# Altium schematic sheet CONNECT.SchDoc
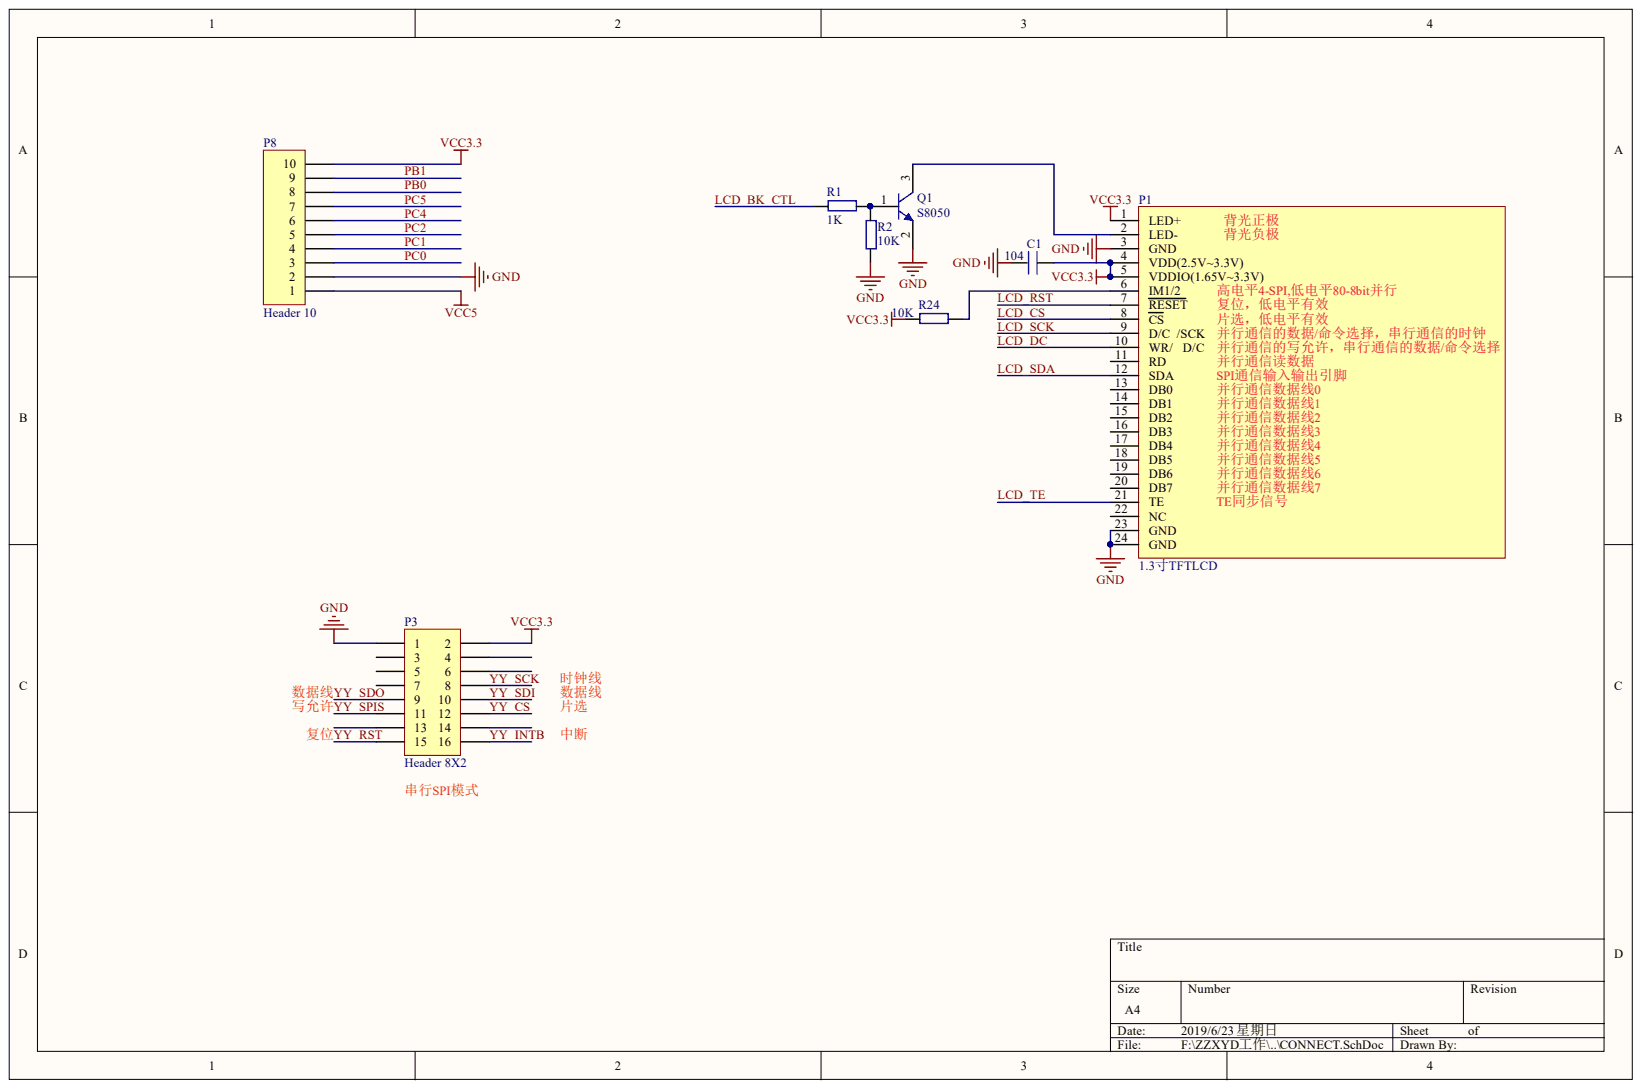
[diagram p0: CONNECT.SchDoc]

## Parts
C1  value 104  [0805C]  (hidden comment: CAP)
P1  1.3寸TFTLCD  [1.3寸屏]
P3  Header 8X2  [语音识别模块]
P8  Header 10  [HDR1X10]
Q1  S8050  [SOT-23]
R1  value 1K  [0805]  (hidden comment: RES)
R2  value 10K  [0805]  (hidden comment: RES)
R24  value 10K  [0805]  (hidden comment: RES)

## Nets
GND: C1.1 P1.3(GND) P1.23(GND) P1.24(GND) P3.1 P8.2 Q1.2 R2.1
VCC3.3: C1.2 P1.1(LED+) P1.4(VDD(2.5V~3.3V)) P1.5(VDDIO(1.65V~3.3V)) P3.2 P8.10 R24.2
VCC5: P8.1
LCD_BK_CTL: R1.2
LCD_CS: P1.8(CS)
LCD_DC: P1.10(WR/)
LCD_RST: P1.7(RESET)
LCD_SCK: P1.9(D/C)
LCD_SDA: P1.12(SDA)
LCD_TE: P1.21(TE)
PB0: P8.8
PB1: P8.9
PC0: P8.3
PC1: P8.4
PC2: P8.5
PC4: P8.6
PC5: P8.7
YY_CS: P3.12
YY_INTB: P3.16
YY_RST: P3.15
YY_SCK: P3.8
YY_SDI: P3.10
YY_SDO: P3.9
YY_SPIS: P3.11
NetP1_2: P1.2(LED-) Q1.3
NetP1_6: P1.6(IM1/2) R24.1
NetQ1_1: Q1.1 R1.1 R2.2

Not connected: P1 11(RD) 13(DB0) 14(DB1) 15(DB2) 16(DB3) 17(DB4) 18(DB5) 19(DB6) 20(DB7) 22(NC); P3 3 4 5 6 7 13 14

## Library data of the parts
P3 = Header 8X2: Header, 8-Pin, Dual row; Component Kind: Standard; LatestRevisionDate: 17-Jul-2002; LatestRevisionNote: Re-released for DXP Platform.; Library Name: Miscellaneous Connectors.IntLib; Signal Integrity: Connector; Publisher: Altium Limited
P8 = Header 10: Header, 10-Pin; Component Kind: Standard; LatestRevisionDate: 17-Jul-2002; LatestRevisionNote: Re-released for DXP Platform.; Library Name: Miscellaneous Connectors.IntLib; Signal Integrity: Connector; Publisher: Altium Limited
Q1 = NPN: NPN三极管; Component Kind: Standard; Library Name: 主控端最小系统.SCHLIB
C1 = CAP: Component Kind: Standard; Description: CAP; Library Name: 主控端最小系统.SCHLIB
P1 = 1.3寸TFTLCD: Component Kind: Standard; Library Name: 主控端最小系统.SCHLIB
R1 R2 R24 = RES: Component Kind: Standard; Description: RES; Library Name: 主控端最小系统.SCHLIB
